# Altium schematic sheet ControlledCharger.SchDoc
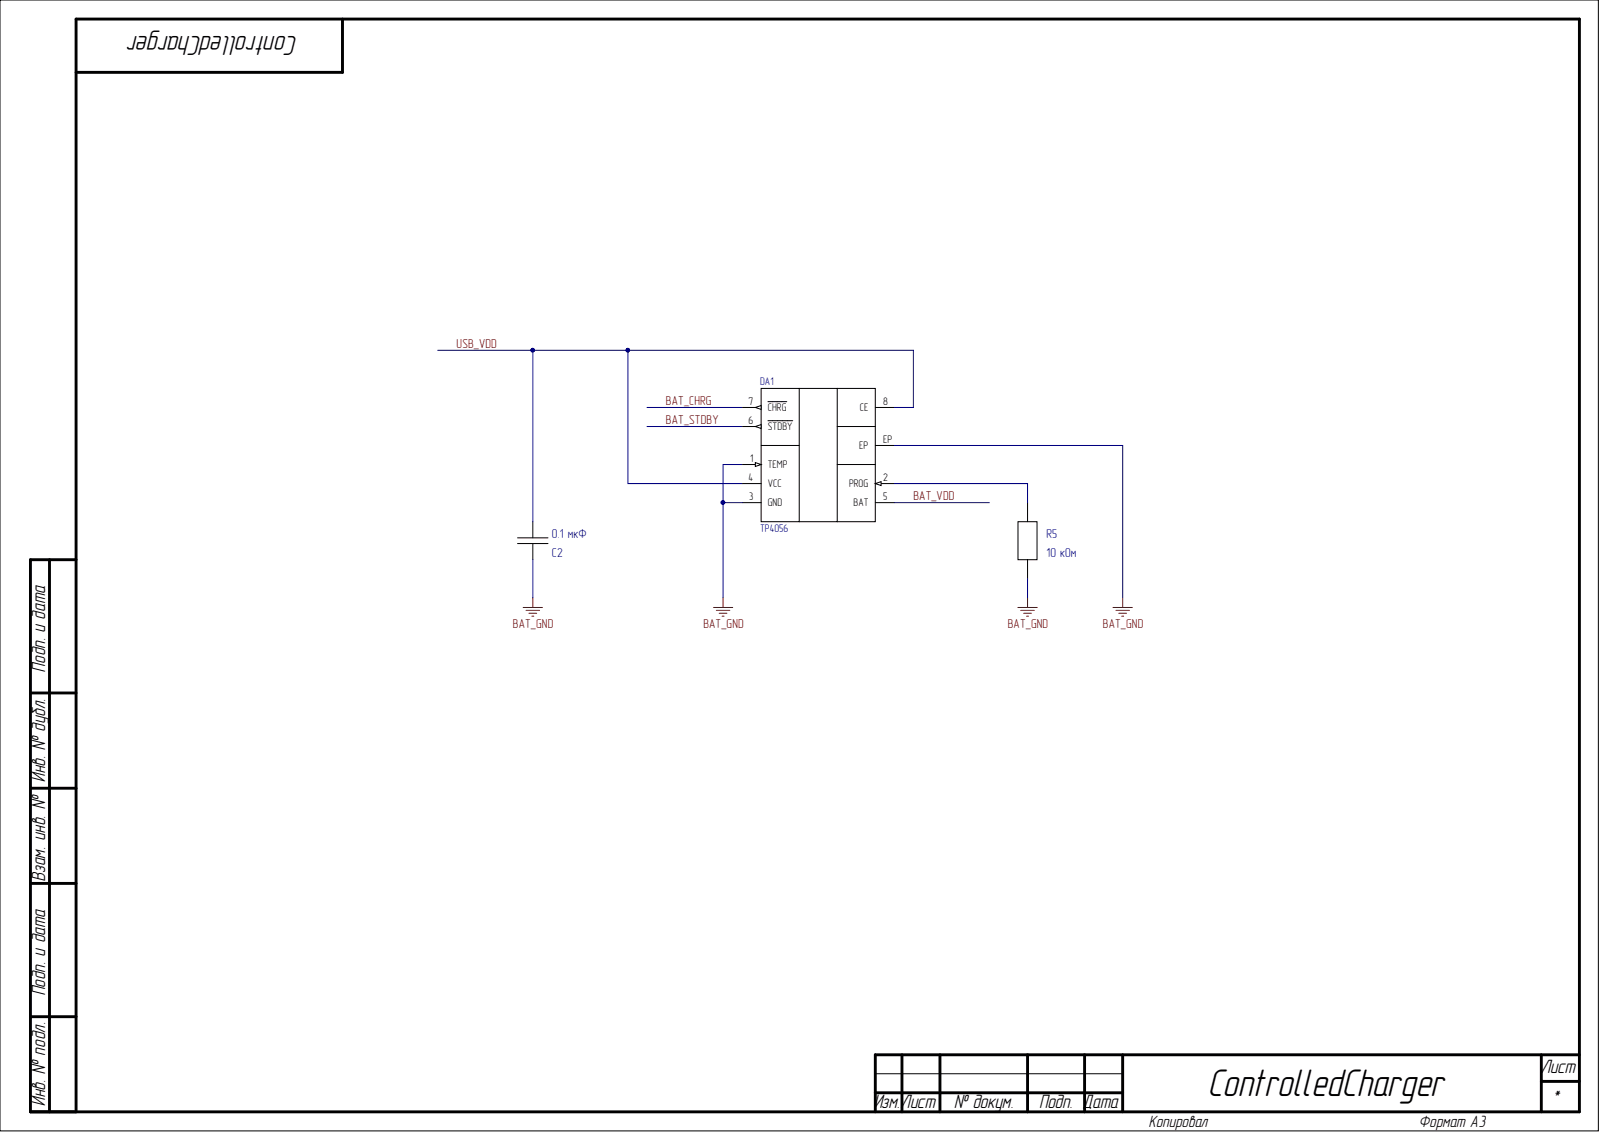
[diagram p0: ControlledCharger.SchDoc]

## Parts
C2  0.1 мкФ  [C 0805]
DA1  TP4056  [HSOP-8]
R5  10 кОм  [RES 0402]

## Nets
BAT_GND: C2.2 DA1.1(TEMP) DA1.3(GND) DA1.EP R5.1
BAT_CHRG: DA1.7(CHRG)
BAT_STDBY: DA1.6(STDBY)
BAT_VDD: DA1.5(BAT)
USB_VDD: C2.1 DA1.4(VCC) DA1.8(CE)
NetDA1_2: DA1.2(PROG) R5.2

## Library data of the parts
C2 = CAP 0805: SMD Capacitor 0805; Manufacturer: /Укажите компанию-производителя/; TC: /Укажите тип диэлектрика/; Temperature coefficient: /Формат: ±? ppm/°C/; Tolerance: /Укажите допуск в процентах/; Type: Конденсатор керамический; Component Kind: Standard; Library Name: my_lib.SchLib; Size: 0805; Temperature range: /Формат: -? ... ? °C/
R5 = RES 0402: Component Kind: Standard; Library Name: my_lib.SchLib
DA1 = TP4056: Component Kind: Standard; Library Name: my_lib.SchLib
